# Altium schematic sheet QC10.SchDoc
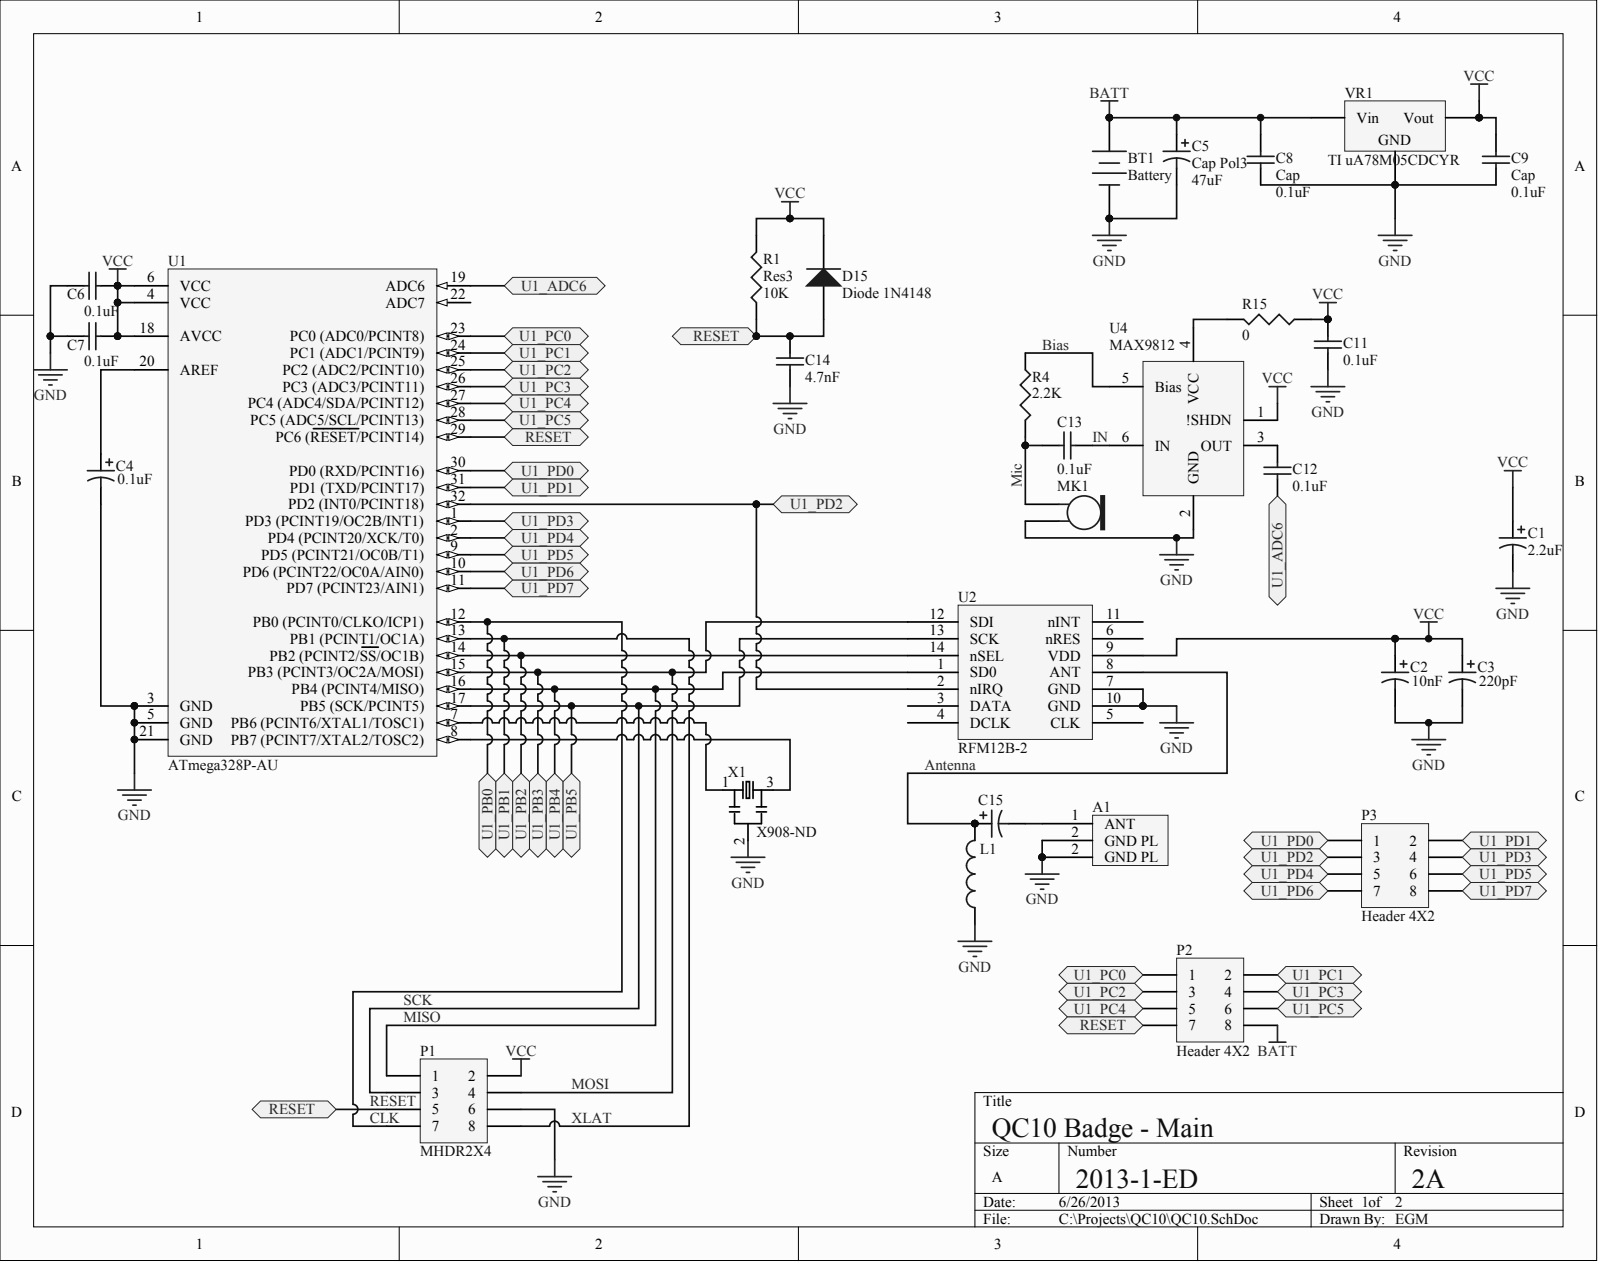
[diagram p0: QC10.SchDoc]

## Parts
A1  ANT
BT1  Battery
C1  value 2.2uF  (hidden comment: Cap Pol3)
C2  value 10nF  (hidden comment: Cap Pol3)
C3  value 220pF  (hidden comment: Cap Pol3)
C4  value 0.1uF  (hidden comment: Cap Pol3)
C5  Cap Pol3  value 47uF
C6  value 0.1uF  (hidden comment: Cap)
C7  value 0.1uF  (hidden comment: Cap)
C8  Cap  value 0.1uF
C9  Cap  value 0.1uF
C11  value 0.1uF  (hidden comment: Cap)
C12  value 0.1uF  (hidden comment: Cap)
C13  value 0.1uF  (hidden comment: Cap)
C14  value 4.7nF  (hidden comment: Cap)
C15  Cap Pol3  (value not drawn)
D15  Diode 1N4148
L1  Inductor  (value not drawn)
MK1  (no value)
P1  MHDR2X4
P2  Header 4X2
P3  Header 4X2
R1  Res3  value 10K
R4  value 2.2K  (hidden comment: Res3)
R15  value 0  (hidden comment: Res3)
U1  ATmega328P-AU
U2  RFM12B-2
U4  MAX9812
VR1  TI uA78M05CDCYR  Manufacturer: TI
X1  X908-ND

## Ports
RESET, U1_ADC6, U1_PB0, U1_PB1, U1_PB2, U1_PB3, U1_PB4, U1_PB5, U1_PC0, U1_PC1, U1_PC2, U1_PC3, U1_PC4, U1_PC5, U1_PD0, U1_PD1, U1_PD2, U1_PD3, U1_PD4, U1_PD5, U1_PD6, U1_PD7

## Nets
BATT: BT1.1 C5.1 C8.2 P2.8 VR1.1
GND: A1.2 BT1.2 C1.2 C2.2 C3.2 C4.2 C5.2 C6.1 C7.1 C8.1 C9.2 C11.1 C14.2 L1.1 MK1.2 P1.6 U1.3(GND) U1.5(GND) U1.21(GND) U2.7(GND) U2.10(GND) U4.2(GND) VR1.2 X1.2
VCC: C1.1 C2.1 C3.1 C6.2 C7.2 C9.1 C11.2 D15.2 P1.2 R1.2 R15.1 U1.4(VCC) U1.6(VCC) U1.18(AVCC) U2.9(VDD) U4.1(!SHDN) VR1.3
ANTENNA: C15.1 L1.2 U2.8(ANT)
BIAS: R4.1 U4.5(Bias)
CLK: P1.7 U1.12(PB0_(PCINT0/CLKO/ICP1)) ; port U1_PB0
IN: C13.1 U4.6(IN)
MIC: C13.2 MK1.1 R4.2
MISO: P1.1 U1.16(PB4_(PCINT4/MISO)) U2.1(SD0) ; port U1_PB4
MOSI: P1.4 U1.15(PB3_(PCINT3/OC2A/MOSI)) U2.12(SDI) ; port U1_PB3
RESET: C14.1 D15.1 P1.5 P2.7 R1.1 U1.29(PC6_(RESET/PCINT14)) ; port RESET
SCK: P1.3 U1.17(PB5_(SCK/PCINT5)) U2.13(SCK) ; port U1_PB5
U1_ADC6: C12.2 U1.19(ADC6) ; port U1_ADC6
U1_PB2: U1.14(PB2_(PCINT2/SS/OC1B)) U2.14(nSEL) ; port U1_PB2
U1_PC0: P2.1 U1.23(PC0_(ADC0/PCINT8)) ; port U1_PC0
U1_PC1: P2.2 U1.24(PC1_(ADC1/PCINT9)) ; port U1_PC1
U1_PC2: P2.3 U1.25 ; port U1_PC2
U1_PC3: P2.4 U1.26(PC3_(ADC3/PCINT11)) ; port U1_PC3
U1_PC4: P2.5 U1.27(PC4_(ADC4/SDA/PCINT12)) ; port U1_PC4
U1_PC5: P2.6 U1.28(PC5_(ADC5/SCL/PCINT13)) ; port U1_PC5
U1_PD0: P3.1 U1.30(PD0_(RXD/PCINT16)) ; port U1_PD0
U1_PD1: P3.2 U1.31(PD1_(TXD/PCINT17)) ; port U1_PD1
U1_PD2: P3.3 U1.32(PD2_(INT0/PCINT18)) U2.2(nIRQ) ; port U1_PD2
U1_PD3: P3.4 U1.1(PD3_(PCINT19/OC2B/INT1)) ; port U1_PD3
U1_PD4: P3.5 U1.2(PD4_(PCINT20/XCK/T0)) ; port U1_PD4
U1_PD5: P3.6 U1.9(PD5_(PCINT21/OC0B/T1)) ; port U1_PD5
U1_PD6: P3.7 U1.10(PD6_(PCINT22/OC0A/AIN0)) ; port U1_PD6
U1_PD7: P3.8 U1.11(PD7_(PCINT23/AIN1)) ; port U1_PD7
XLAT: P1.8 U1.13(PB1_(PCINT1/OC1A)) ; port U1_PB1
NetA1_1: A1.1 C15.2
NetC4_1: C4.1 U1.20(AREF)
NetC12_1: C12.1 U4.3(OUT)
NetR15_2: R15.2 U4.4(VCC)
NetU1_7: U1.7 X1.1
NetU1_8: U1.8 X1.3

Not connected: U1 22(ADC7); U2 3(DATA) 4(DCLK) 5(CLK) 6(nRES) 11(nINT)

## Library data of the parts
BT1 = (no symbol name): Manufacturer: Keystone; PN: 2479; PackageReference: BAT-2; PackageDescription: Battery; 2 Leads
C1 = (no symbol name): Manufacturer: Kemmet; PN: T491A225K016AT; PackageReference: 3216 (1210); PackageDescription: Chip Capacitor
C2 = (no symbol name): Manufacturer: Kemmet; PN: C0201C103K4PACTU; PackageReference: 0603 (mm); PackageDescription: Chip Capacitor
C3 = (no symbol name): Manufacturer: AVX; PN: CU0131220JAT2A\5K; PackageReference: 0603 (mm); PackageDescription: Chip Capacitor
C4 C6-C9 C11-C13 = (no symbol name): Manufacturer: Kemmet; PN: C1206C104K4RACTU; PackageReference: 3216 (1210); PackageDescription: Chip Capacitor
C5 = (no symbol name): Manufacturer: Kemmet; PN: EEV476M035A9GAA; PackageReference: 6.3x5.4mm; PackageDescription: Chip Capacitor
D15 = (no symbol name): Manufacturer: Schottky; PN: SD1206S040S0R5; PackageReference: 3216 (1210); PackageDescription: Diode, Axial; Body 3.8 x 1.9 mm (LxDia. max), Lead Dia. 0.53 mm (max)
L1 = (no symbol name): Code_IEC: 1005; Published: 8-Jun-2000; LatestRevisionDate: 29-May-2009; LatestRevisionNote: IPC-7351 Footprint Added.; PackageReference: 0402-A; PackageDescription: Chip Inductor; Code_JEITA: 0402; Publisher: Altium Limited
P1 = (no symbol name): Manufacturer: 3M; PN: 951208-2520-AR-PR; PackageDescription: 2mm 2x4
P2 P3 = (no symbol name): Manufacturer: Harwin; PN: M22-2010846; PackageDescription: 2mm 1x8
R1 R15 = (no symbol name): Manufacturer: KOA; PN: RK73B2BTTD103J; PackageReference: 0603 (mm); PackageDescription: Chip Resistor
R4 = (no symbol name): Manufacturer: Panasonic; PN: ERJ-T08J222V; PackageReference: 0603 (mm); PackageDescription: Chip Resistor
U1 = (no symbol name): Manufacturer: Atmel; PackageReference: TQFP-32; PackageDescription: 32-Lead, 7x7x1.0 mm Body, 0.8mm Lead Pitch, Thin Profile Plastic Quad Flat Package (TQFP); PN: ATmega328P-AU
U2 = (no symbol name): Manufacturer: HopeRF; PN: RFM12B-433S2; PackageReference: SOP-14
U4 = (no symbol name): Manufacturer: Maxim; PN: MAX9812; PackageReference: SC-70
X1 = (no symbol name): Manufacturer: ECS; PN: X908-ND; PackageReference: 5x10mm
A1 C14 C15 MK1 VR1 = (no symbol name)
  C14: PackageReference: 3216 (1210); PackageDescription: Chip Capacitor
  C15: Published: 8-Jun-2000; LatestRevisionDate: 29-May-2009; LatestRevisionNote: IPC-7351 Footprint Added.; PackageReference: C0805; PackageDescription: Chip Capacitor; Publisher: Altium Limited
  MK1: PackageReference: PIN2
  VR1: PackageReference: D2PAK; PackageDescription: 3-Pin Transistor Outline Package 2.54 mm Pitch, 15.1 mm Lead Span; PN: UA78M05CDCYR
